# Altium schematic sheet Sheet2.SchDoc
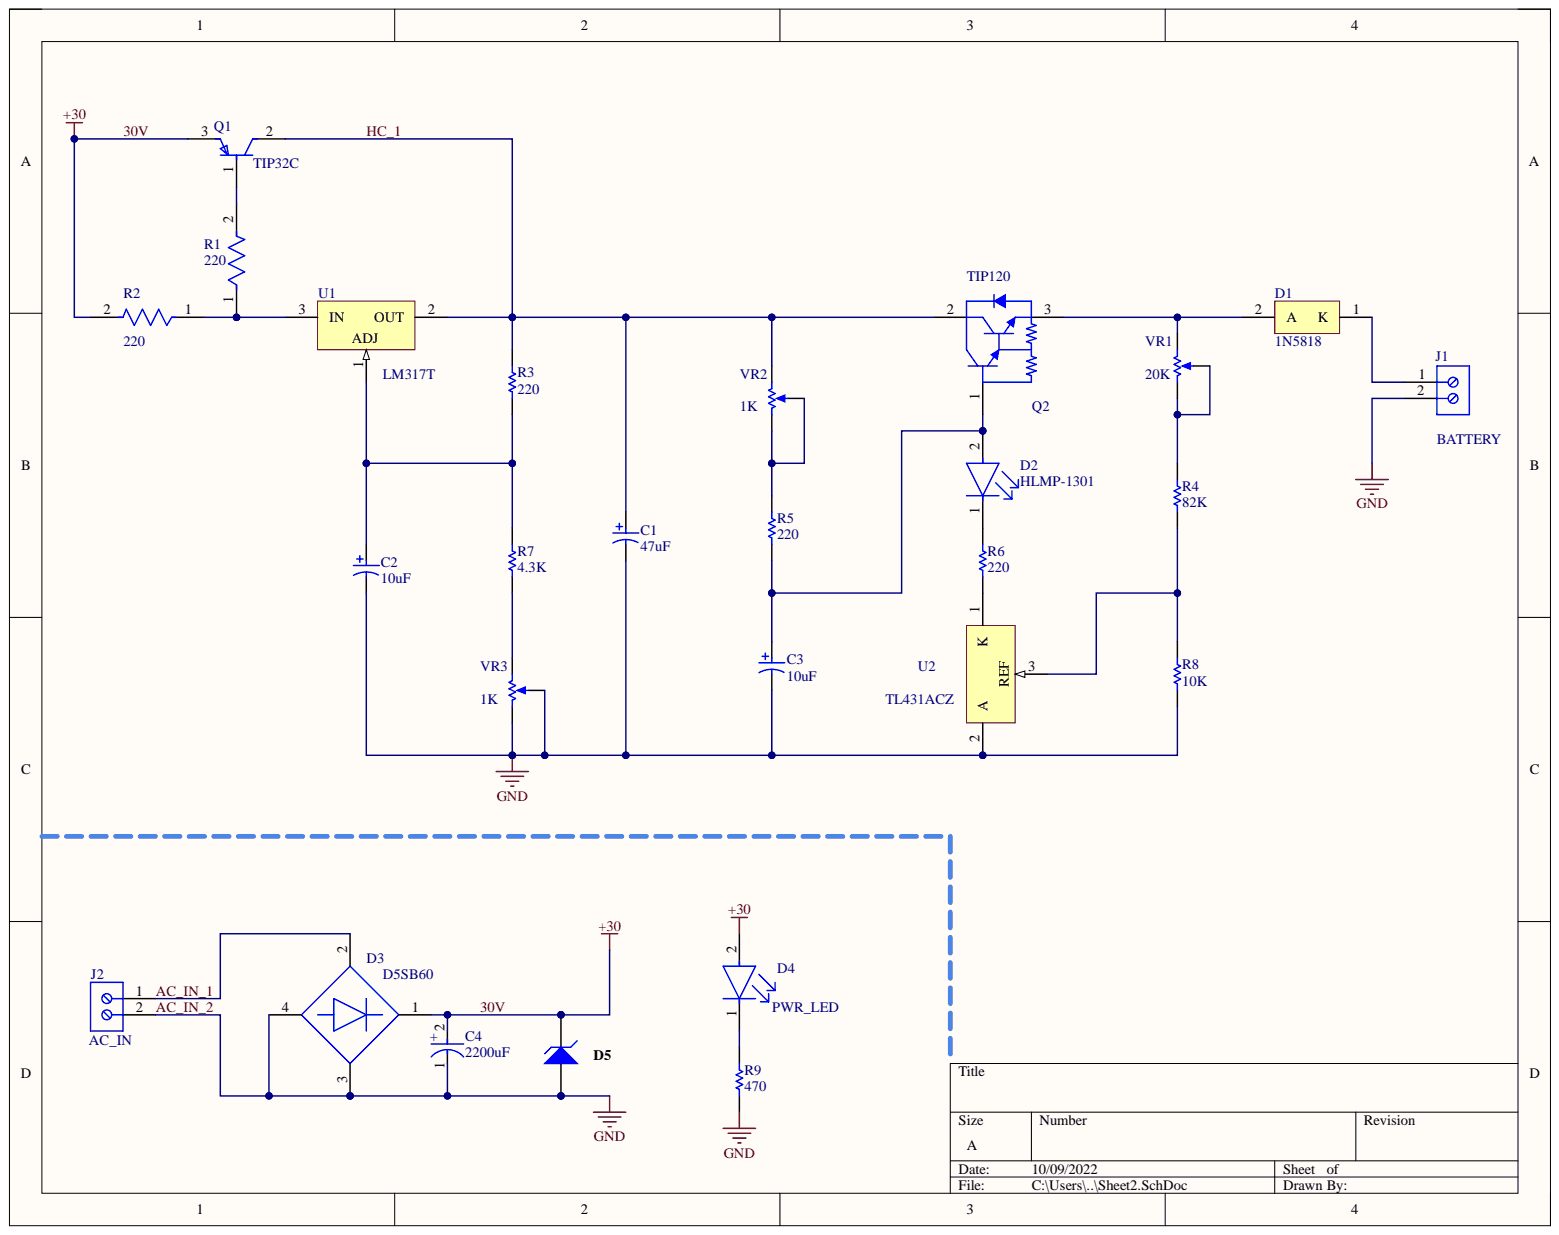
[diagram p0: Sheet2.SchDoc]

## Parts
C1  47uF  [CAPPR55-200-1100X500X1200]
C2  10uF  [CAPPR55-200-1100X500X1200]
C3  10uF  [CAPPR55-200-1100X500X1200]
C4  2200uF  [FP-RAD-TH-D_12_5_0_5-L_40-MFG]
D1  1N5818  [ONSC-AXIAL_LEAD-2-59-10_P]
D2  HLMP-1301  [FP-HLMP-1301-MFG]
D3  D5SB60  [FP-GBU-MFG]
D4  PWR_LED  [FP-HLMP-1503-MFG]
D5  1N4732A  [FAIR-DO-41-2_V]  (value not drawn)
J1  BATTERY  [PHOE-1714955_V]
J2  AC_IN  [PHOE-1714955_V]
Q1  TIP32C  [FP-TO-220-P0A0015988-MFG]
Q2  TIP120  [ONSC-TO-220-3-221A-09]
R1  220  [FP-SP3A-MFG]
R2  220  [FP-SP3A-MFG]
R3  220  [RESA60-630X240]
R4  82K  [RESA60-630X240]
R5  220  [RESA60-630X240]
R6  220  [RESA60-630X240]
R7  4.3K  [RESA60-630X240]
R8  10K  [RESA60-630X240]
R9  470  [RESA60-630X240]
U1  LM317T  [TO-220]
U2  TL431ACZ  [TO-92]
VR1  20K  [BOUR-3852A-282-103AL-3_V]
VR2  1K  [BOUR-3852A-282-103AL-3_V]
VR3  1K  [BOUR-3852A-282-103AL-3_V]

## Nets
30V: C4.2 D3.1 D4.2 D5.2 Q1.3 R2.2
AC_IN_1: D3.2 J2.1
AC_IN_2: C1.2 C2.2 C3.2 C4.1 D3.3 D3.4 D5.1 J1.2 J2.2 R8.1 R9.1 U2.2 VR3.2 VR3.3
HC_1: C1.1 Q1.2 Q2.2 R3.2 U1.2 VR2.1
NetC2_1: C2.1 R3.1 R7.2 U1.1
NetC3_1: C3.1 D2.2 Q2.1 R5.1
NetD1_1: D1.1 J1.1
NetD1_2: D1.2 Q2.3 VR1.1
NetD2_1: D2.1 R6.2
NetD4_1: D4.1 R9.2
NetQ1_1: Q1.1 R1.2
NetR1_1: R1.1 R2.1 U1.3
NetR4_1: R4.1 R8.2 U2.3
NetR4_2: R4.2 VR1.2 VR1.3
NetR5_2: R5.2 VR2.2 VR2.3
NetR6_1: R6.1 U2.1
NetR7_1: R7.1 VR3.1

## Library data of the parts
C1 = CMP-1665-00038-2: Aluminum Electrolytic Capacitor, 10 uF, +/- 20%, 50 V, -40 to 85 degC, 2-Pin THD, RoHS, Bulk; Manufacturer: Panasonic; Capacitance: 10uF; Dielectric Material: Aluminium; Dissipation Factor: 0.12%; Lead Diameter: 0.5mm; Lead/Base Style: Straight; Leakage Current: 3uA; Life (Hours): 2000Hour; Ripple Current: 65mA; Voltage: 50V; Case/Package: Radial; Component Kind: Standard; Datasheet URL: https://industrial.panasonic.com/cdbs/www-data/pdf/RDF0000/ABA0000C1218.pdf; Datasheet Version: Rev. 05, 10/2016; Diameter: 5mm; Height: 11mm; Height - Seated (Max): 0.472inch; Lead Free: LeadFree; Lead Pitch: 2mm; Length: 11mm; Library Name: Altium Content Vault; Manufacturer URL: http://www.panasonic.com/; Max Operating Temperature: 85degC; Min Operating Temperature: -40degC; Mount: ThroughHole; Number of Pins: 2; Package Description: 2-Pin Radial Lead, Dia 5 mm, Height 12 mm; Package Quantity: 200; Package Reference: CAPPR55-200-1100X500X1200; Packaging: Bulk; Radiation Hardening: No; REACH SVHC: NoSVHC; Ripple Current (AC): 65mA; RoHSCompliant: true; Termination: Radial; Tolerance: 20%; Voltage Rating: 50V; Voltage Rating (DC): 50V
C2 C3 = CMP-1665-00038-2: Aluminum Electrolytic Capacitor, 10 uF, +/- 20%, 50 V, -40 to 85 degC, 2-Pin THD, RoHS, Bulk; Manufacturer: Panasonic; Dielectric Material: Aluminium; Dissipation Factor: 0.12%; Lead Diameter: 0.5mm; Lead/Base Style: Straight; Leakage Current: 3uA; Life (Hours): 2000Hour; Ripple Current: 65mA; Voltage: 50V; Capacitance: 10uF; Case/Package: Radial; Component Kind: Standard; Datasheet URL: https://industrial.panasonic.com/cdbs/www-data/pdf/RDF0000/ABA0000C1218.pdf; Datasheet Version: Rev. 05, 10/2016; Diameter: 5mm; Height: 11mm; Height - Seated (Max): 0.472inch; Lead Free: LeadFree; Lead Pitch: 2mm; Length: 11mm; Library Name: Altium Content Vault; Manufacturer URL: http://www.panasonic.com/; Max Operating Temperature: 85degC; Min Operating Temperature: -40degC; Mount: ThroughHole; Number of Pins: 2; Package Description: 2-Pin Radial Lead, Dia 5 mm, Height 12 mm; Package Quantity: 200; Package Reference: CAPPR55-200-1100X500X1200; Packaging: Bulk; Radiation Hardening: No; REACH SVHC: NoSVHC; Ripple Current (AC): 65mA; RoHSCompliant: true; Termination: Radial; Tolerance: 20%; Voltage Rating: 50V; Voltage Rating (DC): 50V
C4 = CMP-05427-000828-1: Aluminum Electrolytic Capacitors (Radial Lead Type) AEC-Q200 Qualified 2200uF ±20% 35V; Capacitance: 2.2mF; Dielectric Material: Aluminium; Impedance: 14mR; Lead Diameter: 0.8mm; Life (Hours): 5000Hour; Ripple Current: 3.01A; Case/Package: Radial; Component Kind: Standard; Construction: RADIAL; Contact Plating: Tin; Diameter: 12.5mm; Height: 40mm; Lead Pitch: 5mm; Length: 40mm; Library Name: Altium Content Vault; Max Operating Temperature: 105°C; Min Operating Temperature: -55°C; Mount: Through Hole; Number of Pins: 2; Package Quantity: 100; Packaging: Bulk; Radiation Hardening: No; Ratings: AEC-Q200; REACH SVHC: No SVHC; Series: FK; Termination: Radial; Tolerance: 20%; Voltage: 35V; Voltage Rating: 35V; Voltage Rating (DC): 35V
D1 = CMP-1055-00034-1: Rectifier, 2-Pin Axial_Lead, Pb-Free, Bulk Bag; Manufacturer: On Semiconductor; Configuration: Single; IFSM Max (A): 25; Note1: 0; Component Kind: Standard; ComponentLink1Description: Manufacturer URL; ComponentLink1URL: http://www.onsemi.com/; ComponentLink2Description: Datasheet; ComponentLink2URL: http://www.onsemi.cn/pub_link/Collateral/1N5817-D.PDF; ComponentLink3Description: Package Specification; ComponentLink3URL: http://www.onsemi.cn/pub_link/Collateral/59-10.PDF; DatasheetVersion: Rev. 10; Library Name: Altium Content Vault; Mounting Technology: Through Hole; PackageDescription: 2-Pin, Axial Lead, Diameter 2.35 mm; PackageReference: AXIAL_LEAD-2-59-10; PackageVersion: Rev. U, 02/2005; Packing: Bulk Bag; PartNumber: 1N5818; RoHS: TRUE
D2 = CMP-18399-000007-1: LED Uni-Color Red 635nm 2-Pin T-1; Manufacturer: Broadcom; Forward Current: 10mA; Forward Voltage: 1.9V; Led Orientation: Straight; Luminous Intensity: 3.4mcd; Max Junction Temp: +110°C; Reverse Voltage: 5V; Viewing Angle: 60°; Wavelength: 626nm; Automotive: No; Category: Diode; Colour: Red; Component Kind: Standard; ComponentLink2Description: Datasheet; ComponentLink2URL: https://docs.broadcom.com/docs/AV02-1555EN; Device Class L1: Optoelectronics; Device Class L2: LEDs; Device Class L3: unset; Digikey Description: LED RED DIFFUSED T-1 T/H; Lead Free: Yes; Library Name: Altium Content Vault; Manufacturer Part Number: HLMP-1301; Mouser Description: Standard LEDs - Through Hole Red Diffused 635nm 3.4mcd; Octopart: https://octopart.com/hlmp-1301-broadcom-71130345; Package: 0; Peak Emmision Wavelength: 635nm; RoHS: TRUE; Temperature: -40°C to +100°C; Temperature Range High: +100°C; Temperature Range Low: -40°C
D3 = CMP-02540-000020-1: Bridge Rectifier Single Phase Standard 800V Through Hole GBU; Manufacturer: Vishay; Manufacturer Part Number: GBU8K-E3/45; Capacitance: 68pF; Forward Current: 3.9A; Max Junction Temp: +150°C; Max Surge Forward Current: 200A; Peak Reverse Current: 5uA; Standoff Height: 1.52mm; Value: 68pF; Automotive: No; Category: Diode; Component Kind: Standard; ComponentLink2Description: Datasheet; ComponentLink2URL: https://www.vishay.com/docs/88616/gbu8a.pdf; Device Class L1: Discrete Semiconductors; Device Class L2: Diodes; Device Class L3: Rectifier Diodes; Digikey Description: BRIDGE RECT 1PHASE 800V 3.9A GBU; Height: 20.83mm; Lead Free: Yes; Library Name: Altium Content Vault; Max Repetitive Reverse Voltage: 800V; Mouser Description: Bridge Rectifiers 800 Volt 8.0 Amp Glass Passivated; Octopart: https://octopart.com/gbu8k-e3%2F45-vishay-42337698; Package: GBU; RoHS: TRUE; Temperature: -55°C to +150°C; Temperature Range High: +150°C; Temperature Range Low: -55°C
D4 = CMP-18399-000010-1: LED GREEN DIFFUSED T-1 T/H; Manufacturer: Broadcom; Forward Current: 10mA; Forward Voltage: 2.1V; Led Orientation: Straight; Luminous Intensity: 1mcd; Max Junction Temp: +110°C; Peak Emmision Wavelength: 565nm; Reverse Voltage: 5V; Standoff Height: 0.88mm; Viewing Angle: 60°; Wavelength: 569nm; Automotive: No; Category: Diode; Colour: Green; Component Kind: Standard; ComponentLink2Description: Datasheet; ComponentLink2URL: https://docs.broadcom.com/docs/AV02-1555EN; Device Class L1: Optoelectronics; Device Class L2: LEDs; Device Class L3: unset; Digikey Description: LED GREEN DIFFUSED T-1 T/H; Height: 6.35mm; Lead Free: Yes; Library Name: Altium Content Vault; Manufacturer Part Number: HLMP-1503; Mouser Description: LED; Green; 1mcd; 0.115In.Dia.; T-1; 565nm; 25mA; 2.1V; 5V; GaP; -20degC; 100deg; Octopart: https://octopart.com/hlmp-1503-broadcom-71130371; Package: HLMP-1503; RoHS: TRUE; Temperature: -20°C to +100°C; Temperature Range High: +100°C; Temperature Range Low: -20°C
D5 = CMP-0892-00030-1: Zener Diode, 4.7V, 1W, 5%, -65 to 200 degC, 2-Pin DO-41, Body 2.72 x 5.2 mm, RoHS; Manufacturer: Fairchild Semiconductor; IR (uA): 10; PD (W): 1; Test Current IZ (mA): 53; VZ (Max.) (V): 4.935; VZ (Min.) (V): 4.465; ZZ (Max) (ohm): 8; Component Kind: Standard; ComponentLink1Description: Manufacturer URL; ComponentLink1URL: http://www.fairchildsemi.com/; ComponentLink2Description: Datasheet; ComponentLink2URL: http://www.fairchildsemi.com/ds/1N/1N4744A.pdf; ComponentLink3Description: Package Specification; ComponentLink3URL: http://www.fairchildsemi.com/dwg/DO/DO41A.pdf; DatasheetVersion: Rev. H3; Library Name: Altium Content Vault; Mounting Technology: Through Hole; Operating and Storage Temperature Range: -65 to 200 degC; PackageDescription: 2-Pin Axial leaded, Body 2.72 x 5.2 mm, Lead Spread 25.4 mm; PackageReference: DO-41-2; PackageVersion: Rev. 2; PartNumber: 1N4732A; RoHS: TRUE; Tolerance: 5%
J1 J2 = CMP-2000-05797-1: PC Terminal Block, Pitch 6.35 mm, 1 x 2 Position, Height 21.5 mm, Tail Lenght 5.1 mm, RoHS, Bulk; Manufacturer: Phoenix Contact; Component Kind: Standard; Datasheet URL: http://media.digikey.com/pdf/Data%20Sheets/Phoenix%20Contact%20PDFs/1714955.pdf; Datasheet Version: 02/2010; Library Name: Altium Content Vault; Manufacturer URL: http://www.phoenixcontact.com/; Mounting Technology: ThroughHole; Package Description: PC Terminal Block, Pitch 6.35 mm, 1 x 2 Position, Height 21.5 mm, Tail Lenght 5.1 mm; Package Reference: 1714955
Q1 = CMP-12195-000005-1: BJT PNP 100V 3A 2W Through Hole TO-220; Manufacturer: STMicroelectronics; Collector Base Voltage: -100V; Collector Emitter Saturation Voltage: -1.2V; Emitter Base Voltage: -5V; Hfe Max: 50; Hfe Min: 10; Hfe Testic: -3A; Hfe Testvce: -4V; Max Junction Temp: +150°C; Number Of Elements: 1; Standoff Height: 3.5mm; Automotive: No; Category: Trans; Collector Emitter Voltage: -100V; Component Kind: Standard; ComponentLink2Description: Datasheet; ComponentLink2URL: https://www.st.com/resource/en/datasheet/tip32c.pdf; Device Class L1: Discrete Semiconductors; Device Class L2: Transistors; Device Class L3: BJTs; Digikey Description: TRANS PNP 100V 3A TO-220; Height: 19.68mm; Lead Free: Yes; Library Name: Altium Content Vault; Manufacturer Part Number: TIP32C; Max Collector Current: -3A; Mouser Description: Original ST Microelectronics Transistor, 100V, 3A, .22 Ohm, TO-220 Package; Number Of Pnp: 1; Octopart: https://octopart.com/tip32c-stmicroelectronics-353617; Package: TO-220-P0A0015988; Power Dissipation: 2W; RoHS: TRUE; Temperature: to +150°C; Temperature Range High: +150°C
Q2 = CMP-1047-00140-1: Plastic Medium-Power, Complementary Silicon Transistor, 3-Pin TO-220, Tube; Manufacturer: On Semiconductor; IC Continuous (A): 5; Polarity: NPN; Component Kind: Standard; ComponentLink1Description: Manufacturer URL; ComponentLink1URL: http://www.onsemi.com/; ComponentLink2Description: Datasheet; ComponentLink2URL: http://www.onsemi.cn/pub_link/Collateral/TIP120-D.PDF; ComponentLink3Description: Package Specification; ComponentLink3URL: http://www.onsemi.com/pub_link/Collateral/221A-09.PDF; DatasheetVersion: Rev. 8; Library Name: Altium Content Vault; Mounting Technology: Through Hole; PackageDescription: 3-Pin Flange Mount, Vertical Straight Lead, Body 9.97 x 4.445 mm, Pitch 2.54 mm; PackageReference: TO-220-3-221A-09; PackageVersion: Rev. AG, 09/2011; Packing: Tube; PartNumber: TIP120; RoHS: FALSE
R1 R2 = CMP-26528-000004-1: RES 10 OHM 5% 4W AXIAL; Resistance: 10R; Temperature Coefficient: 20ppm/°C; Case/Package: Axial; Component Kind: Standard; Diameter: 5.5mm; Length: 12.7mm; Library Name: Altium Content Vault; Max Operating Temperature: 350°C; Max Power Dissipation: 4W; Min Operating Temperature: -55°C; Mount: Through Hole; Number of Terminations: 2; Package Quantity: 1; Packaging: Cut Tape; Power Rating: 4W; Resistor Type: Wirewound; RoHS Compliant: Yes; Series: SP; Tolerance: 5%
R3-R9 = CMP-1659-00075-1: Axial Resistor, 1 KOhm, +/- 1%, 250 mW, -55 to 155 degC, 2-Pin THD, RoHS, Bulk; Power Rating: 250 mW; Resistance: 10 kohm; Voltage Rating: 250 V; Case\Package: Axial; Component Kind: Standard; ComponentLink1Description: Manufacturer URL; ComponentLink1URL: http://www.yageo.com/; ComponentLink2Description: Datasheet; ComponentLink2URL: http://www.yageo.com/documents/recent/Yageo%20LR_MFR_2013.pdf; DatasheetVersion: Apr-13; Length: 0.248 inch; Library Name: Altium Content Vault; Max Operating Temperature: 155 degC; Min Operating Temperature: -55 degC; Package Quantity: 200; PackageDescription: 2-Pin Axial Lead, Dia 2.4 mm, Body Length 6.3 mm; PackageReference: MFR-25; Packaging: Bulk; RoHSCompliant: true; Tolerance: 1%
U1 = CMP-0244-00444-1: 1.5A, 1.2V to 37V Adjustable Voltage Regulator, 3-Pin TO-220; Component Kind: Standard; ComponentLink1Description: Manufacturer URL; ComponentLink1URL: http://www.st.com; ComponentLink2Description: Datasheet; ComponentLink2URL: http://www.st.com/internet/com/TECHNICAL_RESOURCES/TECHNICAL_LITERATURE/DATASHEET/CD00000455.pdf; ComponentLink3Description: Package Specification; ComponentLink3URL: http://www.st.com/internet/com/TECHNICAL_RESOURCES/TECHNICAL_LITERATURE/PACKAGE_INFORMATION/CD00004649.pdf; DatasheetVersion: 1/11/2011; Library Name: Altium Content Vault; PackageDescription: TO, Thru-Hole, Vertical, Heatsink Mounted; 3 In-Line Leads; Pitch 2.54 mm; PackageReference: TO-220; PackageVersion: 2011
U2 = CMP-0242-00006-1: Programmable Voltage Reference, 2.5 to 36V, 3-Pin TO-92; Component Kind: Standard; ComponentLink1Description: Manufacturer URL; ComponentLink1URL: http://www.st.com; ComponentLink2Description: Datasheet; ComponentLink2URL: http://www.st.com/internet/com/TECHNICAL_RESOURCES/TECHNICAL_LITERATURE/DATASHEET/CD00000986.pdf; DatasheetVersion: Oct-2011; Library Name: Altium Content Vault; PackageDescription: TO, Flat Index; 3 In-Line, Axial Leads; Body Dia. 4.7mm; Lead Dia. 0.56 mm (max); PackageReference: TO-92; PackageVersion: 2011
VR1-VR3 = CMP-2000-05079-1: Diameter Panel Control, 10 KOhm, +/- 10%, 2 W, -1 to 125 degC, 3-Pin THD, RoHS, Bulk; Manufacturer: Bourns; Actuator Diameter: 0.250"(6.35mm); Actuator Length: 0.875"(22.23mm); Actuator Type: Slotted; Associated Product: 36-8560-ND  -KNOBINSTRUMW/DIALRND.9"DIA  36-8555-ND  -KNOBINSTRUMSKIRTEDRND.7"DIA  36-8556-ND  -KNOBINSTRUMSKIRTEDRND.9"DIA  36-8565-ND  -KNOBINSTRUMDBLBARRND.7"DIA  36-8566-ND  -KNOBINSTRUMDBLBARRND.9"DIA  36-8568-ND  -KNOBINSTRUMSGLBARRND.7"DIA  36-8569-ND  -KNOBINSTRUMSGLBARRND.9"DIA  36-8559-ND  -KNOBINSTRUMW/DIALRND.7"DIA  226-1006-ND  -KNOBBLKGLOSS.75"DIA.250"SHAFT  226-1007-ND  -KNOBBLKGLOSS.925"DIA.250"SHAFT  226-1011-ND  -KNOBBLKGLOS; Built in Switch: None; Catalog Drawings: 3852SeriesSide1    3852SeriesSide2; Number of Gangs: 1; Online Catalog: 3852and3856Series; Other Names: 3852A282103AL; PCN Design/Specification: PBTResinJun/2011; PCN Other: MultipleChanges01/Dec/2012; Power (Watts): 2W; Resistance (Ohms): 10k; Resistive Material: Cermet; Rotation: 280deg; Temperature Coefficient: ±150ppm/degC; Bushing Thread: 3/8-32; Category: Potentiometers,VariableResistors; Component Kind: Standard; Datasheet URL: https://datasheet.ciiva.com/11831/0900766b80f93b4b-11831016.pdf; Datasheet Version: Sep-14; Family: RotaryPotentiometers,Rheostats; Library Name: Altium Content Vault; Manufacturer URL: http://www.bourns.com/; Mounting Type: PanelMount; Number of Turns: 1; Packaging: Bulk; RoHS Information: 3852A-LMaterialDeclaration; Series: 3852; Standard Package: 45; Taper: Linear; Termination Style: SolderLug; Tolerance: ±10%
